# Altium schematic sheet MCU.SchDoc(MCU)
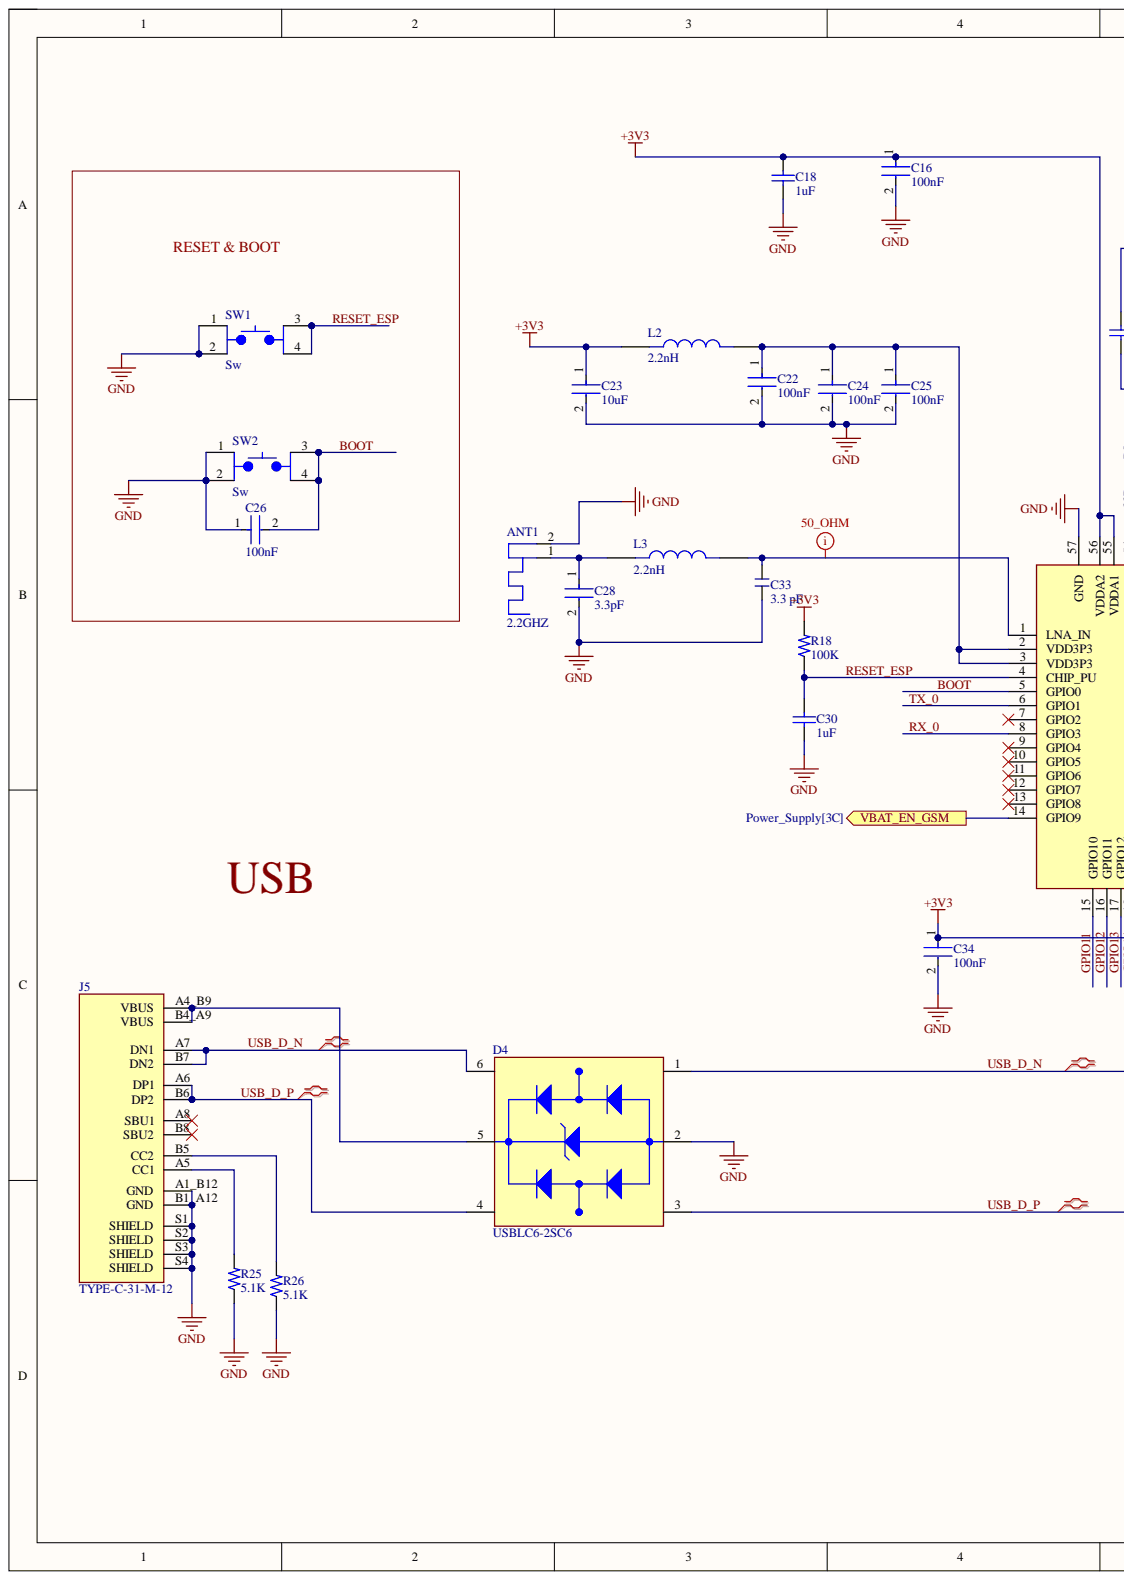
[diagram p0: MCU.SchDoc, left]
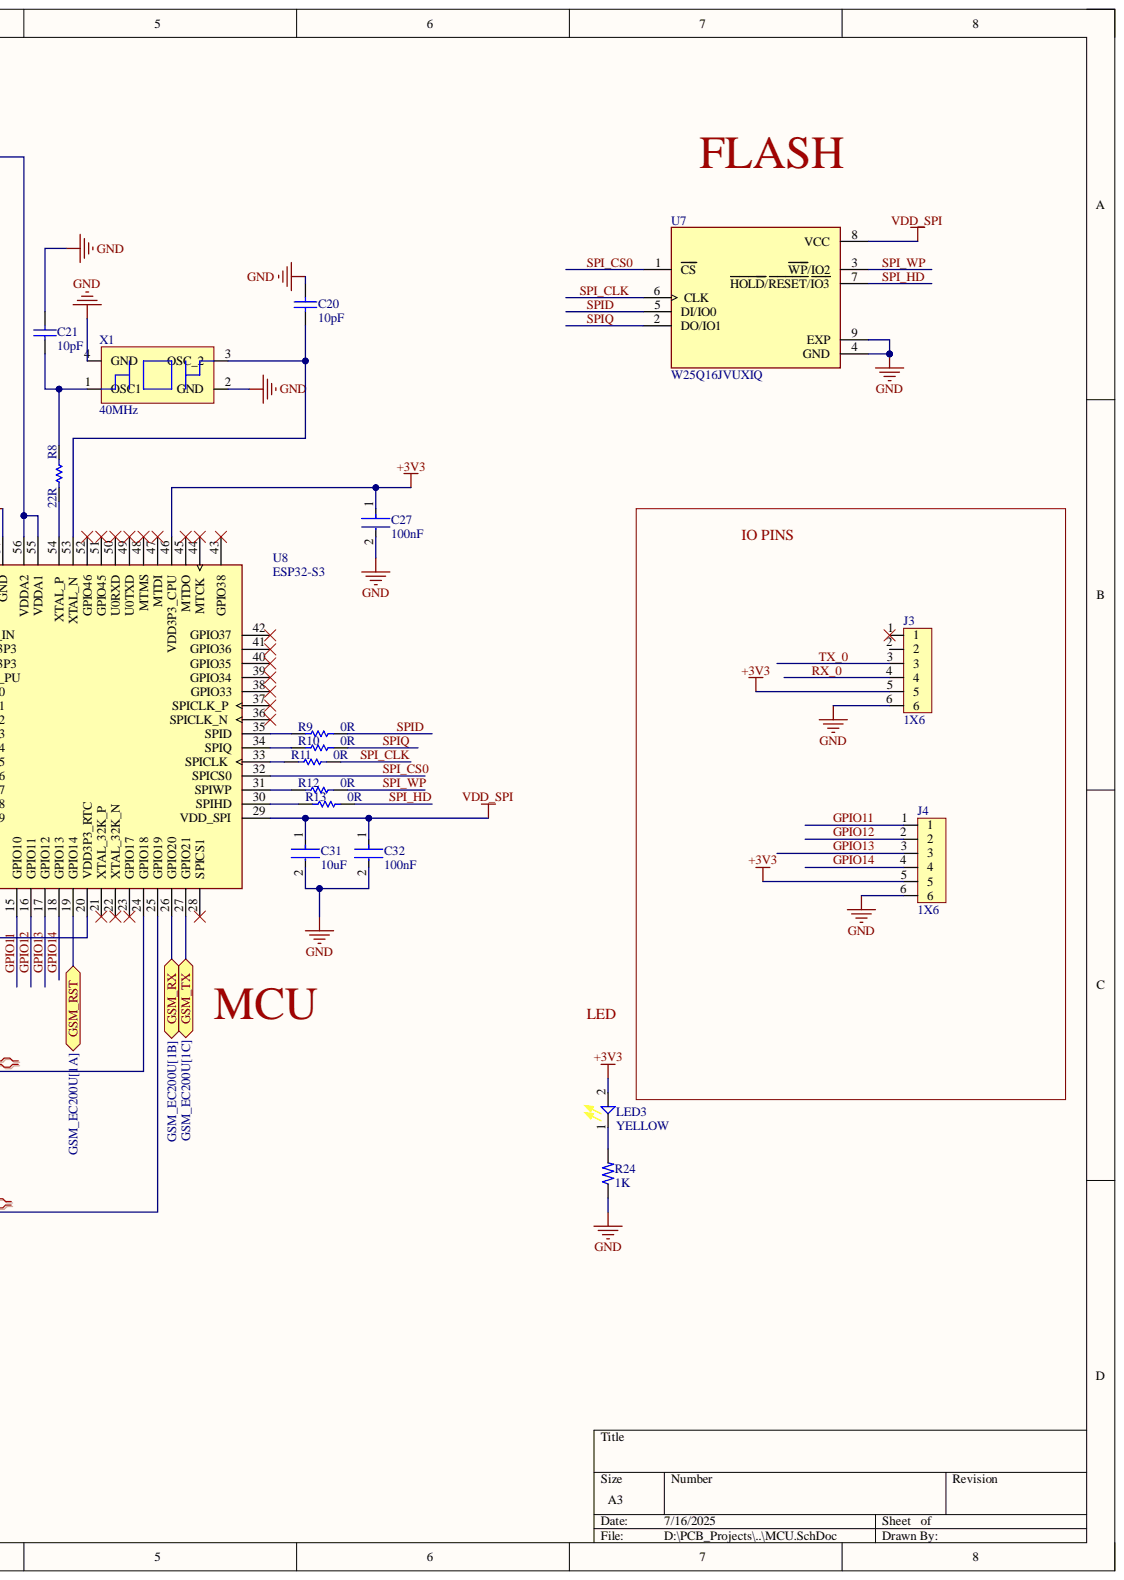
[diagram p1: MCU.SchDoc, right]

## Parts
ANT1  2.2GHZ  [2.4 GHz PCB antenna]
C16  100nF  [FP-CGA3E-0_3-0_1-IPC_A]
C18  1uF  [CAPC1608X90X35LL15T15]
C20  10pF  [CAPC1608X90X35LL10T15]
C21  10pF  [CAPC1608X90X35LL10T15]
C22  100nF  [FP-CGA3E-0_3-0_1-IPC_A]
C23  10uF  [FP-GRM188-0_1-e0_2_0_55-IPC_A]
C24  100nF  [FP-CGA3E-0_3-0_1-IPC_A]
C25  100nF  [FP-CGA3E-0_3-0_1-IPC_A]
C26  100nF  [FP-CGA3E-0_3-0_1-IPC_A]
C27  100nF  [FP-CGA3E-0_3-0_1-IPC_A]
C28  3.3pF  [FP-C0603C-CF-MFG]
C30  1uF  [CAPC1608X90X35LL15T15]
C31  10uF  [FP-GRM188-0_1-e0_2_0_55-IPC_A]
C32  100nF  [FP-CGA3E-0_3-0_1-IPC_A]
C33  3.3 pF  [Capacitor_0805]
C34  100nF  [FP-CGA3E-0_3-0_1-IPC_A]
D4  USBLC6-2SC6  [SOT-23-6]
J3  1X6  [Header_1X6_2.54mm]
J4  1X6  [Header_1X6_2.54mm]
J5  TYPE-C-31-M-12  [TYPE-C-31-M-12]
L2  2.2nH  [Inductor_0603]
L3  2.2nH  [Inductor_0603]
LED3  YELLOW  [LED_YELLOW_0603]
R8  22R  [RESC1608X55X25NL10T15]
R9  0R  [RESC1609X50X30NL8T20]
R10  0R  [RESC1609X50X30NL8T20]
R11  0R  [RESC1609X50X30NL8T20]
R12  0R  [RESC1609X50X30NL8T20]
R13  0R  [RESC1609X50X30NL8T20]
R18  100K  [Resistor_0603]
R24  1K  [Resistor_0805]
R25  5.1K  [Resistor_0805]
R26  5.1K  [Resistor_0805]
SW1  Sw  [SW_Tactile_SMD]
SW2  Sw  [SW_Tactile_SMD]
U7  W25Q16JVUXIQ  [8_USON]
U8  ESP32-S3  [ESP32S3]
X1  40MHz  [SMD2520-4P]

## Ports
GSM_RST, GSM_RX, GSM_TX, VBAT_EN_GSM

## Nets
+3V3: C16.1 C18.2 C23.1 C27.1 C34.1 J3.5 J4.5 L2.1 LED3.2 R18.2 U8.20(VDD3P3_RTC) U8.46(VDD3P3_CPU) U8.55(VDDA1) U8.56(VDDA2)
GND: ANT1.2 C16.2 C18.1 C20.2 C21.2 C22.2 C23.2 C24.2 C25.2 C26.1 C27.2 C28.2 C30.1 C31.2 C32.2 C33.1 C34.2 D4.2 J3.6 J4.6 J5.A1_B12(GND) J5.B1_A12(GND) J5.S1(SHIELD) J5.S2(SHIELD) J5.S3(SHIELD) J5.S4(SHIELD) R24.1 R25.1 R26.1 SW1.1 SW1.2 SW2.1 SW2.2 U7.4(GND) U7.9(EXP) U8.57(GND) X1.2(GND) X1.4(GND)
VDD_SPI: C31.1 C32.1 U7.8(VCC) U8.29(VDD_SPI)
BOOT: C26.2 SW2.3 SW2.4 U8.5(GPIO0)
GPIO11: J4.1 U8.15(GPIO10)
GPIO12: J4.2 U8.16(GPIO11)
GPIO13: J4.3 U8.17(GPIO12)
GPIO14: J4.4 U8.18(GPIO13)
GSM_RST: U8.19(GPIO14) ; port GSM_RST
GSM_RX: U8.26(GPIO20) ; port GSM_RX
GSM_TX: U8.27(GPIO21) ; port GSM_TX
RESET_ESP: C30.2 R18.1 SW1.3 SW1.4 U8.4(CHIP_PU)
RX_0: J3.4 U8.8(GPIO3)
SPI_CLK: R11.2 U7.6(CLK)
SPI_CS0: U7.1(CS) U8.32(SPICS0)
SPI_HD: R13.2 U7.7(HOLD/RESET/IO3)
SPI_WP: R12.2 U7.3(WP/IO2)
SPID: R9.2 U7.5(DI/IO0)
SPIQ: R10.2 U7.2(DO/IO1)
TX_0: J3.3 U8.6(GPIO1)
USB_D_N: D4.1 D4.6 J5.A7(DN1) J5.B7(DN2) U8.24(GPIO18)
USB_D_P: D4.3 D4.4 J5.A6(DP1) J5.B6(DP2) U8.25(GPIO19)
VBAT_EN_GSM: U8.14(GPIO9) ; port VBAT_EN_GSM
NetANT1_1: ANT1.1 C28.1 L3.1
NetC20_1: C20.1 U8.53(XTAL_N) X1.3(OSC_2)
NetC21_1: C21.1 R8.2 X1.1(OSC1)
NetC22_1: C22.1 C24.1 C25.1 L2.2 U8.2(VDD3P3) U8.3(VDD3P3)
NetC33_2: C33.2 L3.2 U8.1(LNA_IN)
NetD4_5: D4.5 J5.A4_B9(VBUS) J5.B4_A9(VBUS)
NetJ5_A5: J5.A5(CC1) R25.2
NetJ5_B5: J5.B5(CC2) R26.2
NetLED3_1: LED3.1 R24.2
NetR8_1: R8.1 U8.54(XTAL_P)
NetR9_1: R9.1 U8.35(SPID)
NetR10_1: R10.1 U8.34(SPIQ)
NetR11_1: R11.1 U8.33(SPICLK)
NetR12_1: R12.1 U8.31(SPIWP)
NetR13_1: R13.1 U8.30(SPIHD)

Not connected: J3 1 2; J5 A8(SBU1) B8(SBU2); U8 7(GPIO2) 9(GPIO4) 10(GPIO5) 11(GPIO6) 12(GPIO7) 13(GPIO8) 21(XTAL_32K_P) 22(XTAL_32K_N) 23(GPIO17) 28(SPICS1) 36(SPICLK_N) 37(SPICLK_P) 38(GPIO33) 39(GPIO34) 40(GPIO35) 41(GPIO36) 42(GPIO37) 43(GPIO38) 44(MTCK) 45(MTDO) 47(MTDI) 48(MTMS) 49(U0TXD) 50(U0RXD) 51(GPIO45) 52(GPIO46)

## Library data of the parts
ANT1 = 2.4 GHz PCB antenna: Component Kind: Standard; Library Name: Schlib1.SchLib
C16 C22 C24-C27 C32 C34 = CMP-2000-07546-2: Cap Ceramic 100nF 50V X7R 10% Pad SMD 0603 +125°C Automotive T/R; Capacitance: 100nF; Case Code (Imperial): 0603; Case Code (Metric): 1608; Case/Package: 0603; Component Kind: Standard; Composition: Ceramic; Depth: 0.8mm; Dielectric: X7R; Height: 0.8mm; Lead Free: Lead Free; Length: 1.6mm; Library Name: Altium Content Vault; Max Operating Temperature: 125°C; Min Operating Temperature: -55°C; Mount: Surface Mount; Package Quantity: 1; Packaging: Tape and Reel; Radiation Hardening: No; Ratings: AEC-Q200; REACH SVHC: No SVHC; Termination: SMD/SMT; Thickness: 0.035inch; Tolerance: 10%; Voltage: 50V; Voltage Rating: 50V; Voltage Rating (DC): 50V; Weight: 7.1E-05oz; Width: 0.8mm
C18 C30 = CMP-2006-02298-2: Manufacturer: Kemet; Part Number: C0603C105K4RACTU; Component Kind: Standard; Library Name: Altium Content Vault
C20 C21 = CMP-2006-02592-1: Manufacturer: Murata; Part Number: GRM1885C1H100JA01D; Component Kind: Standard; Library Name: Altium Content Vault
C23 C31 = CMP-2006-02792-2: Chip Multilayer Ceramic Capacitors for General Purpose, 0603, 10uF, X5R, 15%, 20%, 6.3V; Capacitance: 10uF; Case Code (Imperial): 0603; Case Code (Metric): 1608; Case/Package: 0603; Component Kind: Standard; Depth: 0.8mm; Dielectric: X5R; Height: 0.8mm; Lead Free: Lead Free; Length: 1.6mm; Library Name: Altium Content Vault; Material: Ceramic; Max Operating Temperature: 85°C; Min Operating Temperature: -55°C; Mount: Surface Mount; Package Quantity: 4000; Packaging: Tape and Reel; Radiation Hardening: No; REACH SVHC: No SVHC; Termination: SMD/SMT; Thickness: 0.035inch; Tolerance: 20%; Voltage: 6.3V; Voltage Rating: 6.3V; Voltage Rating (DC): 6.3V; Width: 0.031inch
C28 = CMP-1035-00238-3: CAP CER 3.3PF 50V NP0 0603; Manufacturer: KEMET; Manufacturer Part Number: C0603C339C5GACTU; Automotive Grade: Grade 1; Material: Ceramic; Temperature Characteristic: C0G; Temperature Coefficient: 30ppm/°C; Tolerance: 0.25 pF; Automotive: Yes; Capacitance: 3.3 pF; Category: Cap; Component Kind: Standard; ComponentLink2Description: Datasheet; ComponentLink2URL: https://api.kemet.com/component-edge/download/datasheet/C0603C100J5GACAUTO.pdf; Device Class L1: Passive Components; Device Class L2: Capacitors; Device Class L3: Ceramic Capacitors; Digikey Description: CAP CER 3.3PF 50V NP0 0603; Height: 0.87mm; Lead Free: Yes; Library Name: Altium Content Vault; Mouser Description: Multilayer Ceramic Capacitors MLCC - SMD/SMT 50volts 3.3pF C0G 0.25%; Octopart: https://octopart.com/c0603c339c5gactu-kemet-3701205; Package: C0603C-CF; RoHS: TRUE; Temperature: -55°C to +125°C; Temperature Range High: +125°C; Temperature Range Low: -55°C; Value: 3.3 pF; Voltage Rating: 50 V
C33 = CAP 3.3 pF 0805: Multilayer Ceramic Capacitor, 3.3 pF, 50 V, ± 0.1pF, C0G (NP0), 0805 [2012 Metric]; Manufacturer: Yageo; Manufacturer Part Number: CC0805BRNPO9BN3R3; Category: Ceramic Capacitors; Component Kind: Standard; ComponentLink1Description: http://www.mouser.com/ds/2/447/Yageo_UPY-GP_NP0_16V-to-50V_9-358835.pdf?src-supplier=Mouser; ComponentLink1URL: http://www.mouser.com/ds/2/447/Yageo_UPY-GP_NP0_16V-to-50V_9-358835.pdf?src-supplier=Mouser; ComponentLink2Description: https://datasheet.octopart.com/CC0805BRNPO9BN3R3-Yageo-datasheet-151948684.pdf?src-supplier=Newark; ComponentLink2URL: https://datasheet.octopart.com/CC0805BRNPO9BN3R3-Yageo-datasheet-151948684.pdf?src-supplier=Newark; ComponentLink3Description: https://datasheet.octopart.com/CC0805BRNPO9BN3R3-Yageo-datasheet-151948684.pdf?src-supplier=Farnell; ComponentLink3URL: https://datasheet.octopart.com/CC0805BRNPO9BN3R3-Yageo-datasheet-151948684.pdf?src-supplier=Farnell; ComponentLink4Description: http://www.yageo.com/documents/recent/UPY-GP_NP0_16V-to-50V_8.pdf?src-supplier=Avnet; ComponentLink4URL: http://www.yageo.com/documents/recent/UPY-GP_NP0_16V-to-50V_8.pdf?src-supplier=Avnet; ComponentLink5Description: https://www.yageo.com/upload/media/product/productsearch/datasheet/mlcc/UPY-GP_NP0_16V-to-50V_18.pdf?src-supplier=Digikey; ComponentLink5URL: https://www.yageo.com/upload/media/product/productsearch/datasheet/mlcc/UPY-GP_NP0_16V-to-50V_18.pdf?src-supplier=Digikey; ComponentLink6Description: http://www.yageo.com/exep/pages/download/literatures/ProductSelectionGuide%202014_Final_2.pdf?src-supplier=Digikey; ComponentLink6URL: http://www.yageo.com/exep/pages/download/literatures/ProductSelectionGuide%202014_Final_2.pdf?src-supplier=Digikey; ComponentLink7Description: http://www.yageo.com/documents/recent/UPY-GP_NP0_16V-to-50V_9.pdf?src-supplier=Future+Electronics; ComponentLink7URL: http://www.yageo.com/documents/recent/UPY-GP_NP0_16V-to-50V_9.pdf?src-supplier=Future+Electronics; ComponentLink8Description: https://datasheet.octopart.com/CC0805BRNPO9BN3R3-Yageo-datasheet-137700082.pdf?src-supplier=Future+Electronics; ComponentLink8URL: https://datasheet.octopart.com/CC0805BRNPO9BN3R3-Yageo-datasheet-137700082.pdf?src-supplier=Future+Electronics; Halogen Free: 5694HalogenFree; Library Name: Schlib1.SchLib; Pricing: 1=0,13, 10=0,075, 100=0,0453, 1000=0,03028 (USD); RoHS: Yes; Stock: 19725; Supplier: Digikey; Supplier Part Number: 311-4130-1-ND
D4 = USBLC6-2SC6: ESD Suppressor Diode Array Dual Uni-Dir 15kV Air Gap/15kV Contact Disc 6-Pin SOT-23 T/R; Manufacturer: STMicroelectronics; Breakdown Voltage: 6V; Clamping Voltage: 17V; Data Rate: 480Mbps; Direction: Unidirectional,Bidirectional; ESD Protection: Yes; Leakage Current: 150nA; Max Repetitive Reverse Voltage (Vrrm): 5.25V; Number of Bidirectional Channels: 2; Number of Elements: 1; Operating Supply Voltage: 1.1V; Peak Pulse Current: 5A; Test Current: 1mA; Value: 3.5pF; Working Voltage: 5V; Case/Package: SOT-23; Category: TVS Diodes; Clamping Voltage-Max: 17V; Component Kind: Standard; ComponentLink1Description: https://datasheet.ciiva.com/1268/1690354-1268384.pdf?src-supplier=Element14; ComponentLink1URL: https://datasheet.ciiva.com/1268/1690354-1268384.pdf?src-supplier=Element14; ComponentLink2Description: https://datasheet.ciiva.com/4508/cd00050750-113117-4508433.pdf?src-supplier=Mouser; ComponentLink2URL: https://datasheet.ciiva.com/4508/cd00050750-113117-4508433.pdf?src-supplier=Mouser; ComponentLink3Description: https://datasheet.ciiva.com/15143/getdatasheetpartid-148465-15143789.pdf?src-supplier=Verical; ComponentLink3URL: https://datasheet.ciiva.com/15143/getdatasheetpartid-148465-15143789.pdf?src-supplier=Verical; ComponentLink4Description: https://datasheet.ciiva.com/16200/cd00050750-16200026.pdf?src-supplier=Future+Electronics; ComponentLink4URL: https://datasheet.ciiva.com/16200/cd00050750-16200026.pdf?src-supplier=Future+Electronics; ComponentLink5Description: https://datasheet.ciiva.com/41444/001184109-da-01-en-ic-esd-protecti-usblc6-2sc6-sot-23-6-stm-41444156.pdf?src-supplier=Conrad; ComponentLink5URL: https://datasheet.ciiva.com/41444/001184109-da-01-en-ic-esd-protecti-usblc6-2sc6-sot-23-6-stm-41444156.pdf?src-supplier=Conrad; Depth: 1.75mm; Height: 1.3mm; Lead Free: Lead Free; Length: 3.05mm; Library Name: Schlib1.SchLib; Manufacturer Part Number: USBLC6-2SC6; Max Breakdown Voltage: 6V; Max Operating Temperature: 125°C; Max Reverse Leakage Current: 150nA; Min Breakdown Voltage: 6V; Min Operating Temperature: -40°C; Mounting Technology: Surface Mount; Number of Channels: 2; Package Quantity: 3000; Packaging: Tape and Reel; Pins: 6; Polarity: Unidirectional,Bidirectional; Power Line Protection: Yes; Pricing: 3000=0,0796, 6000=0,0796, 9000=0,0796 (USD); Radiation Hardening: No; Reach SVHC Compliant: No; Reverse Breakdown Voltage: 6V; Reverse Standoff Voltage: 5.25V; RoHS: Yes; RoHS Compliant: Yes; Series: USB; Stock: 222000; Supplier: Arrow Electronics; Supplier Part Number: USBLC6-2SC6; Termination: SMD/SMT; Width: 1.75mm
J3 J4 = Header Male 1X6: THT Vertical Pin Header WR-PHD, Pitch 2.54 mm, Single Row, 6 pins; Manufacturer: Wurth Electronics; Manufacturer Part Number: 61300611121; Contact Resistance: 20mR; Current Rating: 3A; Gender: 29Gender; Number of Contacts: 6; Operating Supply Voltage: 250V; Pitch: 0.1inch; Category: Headers and Wire Housings; Color: Black; Component Kind: Standard; ComponentLink1Description: http://www.mouser.com/ds/2/445/6130xx11121-538006.pdf?src-supplier=Mouser; ComponentLink1URL: http://www.mouser.com/ds/2/445/6130xx11121-538006.pdf?src-supplier=Mouser; ComponentLink2Description: http://www.farnell.com/cad/1737268.pdf?src-supplier=Element14; ComponentLink2URL: http://www.farnell.com/cad/1737268.pdf?src-supplier=Element14; ComponentLink3Description: https://datasheet.octopart.com/61300611121-W%C3%BCrth-Elektronik-datasheet-21154836.pdf?src-supplier=Element14; ComponentLink3URL: https://datasheet.octopart.com/61300611121-W%C3%BCrth-Elektronik-datasheet-21154836.pdf?src-supplier=Element14; ComponentLink4Description: https://katalog.we-online.de/em/datasheet/6130xx11121.pdf?src-supplier=Digikey; ComponentLink4URL: https://katalog.we-online.de/em/datasheet/6130xx11121.pdf?src-supplier=Digikey; Contact Gender: Male; Contact Material: Copper Alloy,Copper; Contact Mating Length: 0.236inch; Contact Plating: Gold; Contact Type: Male Pin; Height: 8.89mm; Housing Material: PBT; Lead Free: 5697LeadFree; Library Name: Schlib1.SchLib; Max Operating Temperature: 125°C; Min Operating Temperature: -40°C; Mounting Technology: Through Hole; Number of Positions: 6; Number of Rows: 1; Orientation: 5495OrientationType; Packaging: Bulk; Pricing: 1=0,35, 10=0,298, 50=0,207, 100=0,187, 1000=0,171, 10000=0,17 (USD); Reach SVHC Compliant: Unknown; RoHS: Yes; RoHS Compliant: Yes; Series: WR-PHD; Stock: 8481; Supplier: Mouser; Supplier Part Number: 710-61300611121; Termination: Through Hole; Voltage Rating: 250V
J5 = TYPE-C-31-M-12: Manufacturer: HRO Electronics; Category: LDO; Component Kind: Standard; Library Name: Schlib1.SchLib; Manufacturer Part Number: TYPE-C-31-M-12; Pricing: 5=0,171, 50=0,1346, 150=0,1168, 1000=0,0966, 2000=0,0923, 5000=0,0896 (USD); RoHS: No; Stock: 137245; Supplier: LCSC; Supplier Part Number: C165948
L2 L3 = Inductor 2.2nH 0603: 2nH 0603 Inductors (SMD) ROHS; Manufacturer: FH (Guangdong Fenghua Advanced Tech); Manufacturer Part Number: VHF160808H2N0ST; Component Kind: Standard; Library Name: Schlib1.SchLib
LED3 = LED_Yellow_0603: TJ-S1608SW6TGLCCW-A5; Manufacturer: Togialed; Component Kind: Standard; Library Name: Schlib1.SchLib; Manufacturer Part Number: TJ-S1608SW6TGLCCW-A5; Pricing: 10=0,0384, 100=0,0311, 300=0,0275, 1000=0,0248, 4000=0,0209, 8000=0,0198 (USD); RoHS: No; Stock: 15460; Supplier: LCSC; Supplier Part Number: C192669
R8 = CMP-1659-00033-1: Chip Resistor, 22 Ohm, +/- 1%, 0.1 W, -55 to 155 degC, 0603 (1608 Metric), RoHS, Tape and Reel; Case\Package: SIP; Max Input Voltage: 1.4 V; Max Ouput Current: 8 A; Component Kind: Standard; ComponentLink1Description: Manufacturer URL; ComponentLink1URL: http://www.yageo.com/; ComponentLink2Description: Datasheet; ComponentLink2URL: http://www.yageo.com/documents/recent/PYu-RC_Group_51_RoHS_L_04.pdf; DatasheetVersion: Rev. 4, 02/2016; Height: 19.6 mm; Length: 18.5 mm; Library Name: Altium Content Vault; Max Operating Temperature: 100 degC; Min Operating Temperature: -25 degC; Mount: Through Hole; Output Current: 8 A; Package Quantity: 200; PackageDescription: 2-Pin Surface Mount Device, Body 1.6 x 0.8 mm; PackageReference: 0603; Packaging: Rail/Tube; Pins: 4; Radiation Hardening: No; REACH SVHC: Unknown; RoHSCompliant: true
R9-R13 = CMP-2000-03816-5: Chip Resistor, 0 Ohm, Jumper, 100 mW, -55 to 155 degC, 0603 (1608 Metric), RoHS, Tape and Reel; Manufacturer: Vishay Semiconductor; Voltage Rating: 75 V; Component Kind: Standard; ComponentLink1Description: Manufacturer URL; ComponentLink1URL: http://www.vishay.com/; ComponentLink2Description: Datasheet; ComponentLink2URL: http://www.vishay.com/docs/20035/dcrcwe3.pdf; DatasheetVersion: 06/2012; Height: 0.45 mm; Length: 1.55 mm; Library Name: Altium Content Vault; Max Operating Temperature: 155 degC; Min Operating Temperature: -55 degC; Mount: Surface Mount; Mounting Technology: Surface Mount; Package Quantity: 5000; PackageDescription: 2-Pin Surface Mount Device, Body 1.575 x 0.85 mm; PackageReference: 0603; Packaging: Tape and Reel; Pins: 2; REACH SVHC: Unknown; RoHSCompliant: true
R18 = R_100K_0603: Res Thick Film 0603 100K Ohm 1% 0.1W(1/10W) ±100ppm/C Pad SMD Automotive T/R; Manufacturer: Stackpole Electronics; Manufacturer Part Number: RMCF0603FT100K; Max Power Dissipation: 100mW; Temperature Coefficient: 100ppm/°C; Value: 100kR; Voltage Rating: 75V; Case Code (Imperial): 0603; Case Code (Metric): 1608; Case/Package: 0603; Category: Chip SMD Resistors; Component Kind: Standard; ComponentLink1Description: https://datasheet.ciiva.com/15193/getdatasheetpartid-362597-15193437.pdf?src-supplier=Verical; ComponentLink1URL: https://datasheet.ciiva.com/15193/getdatasheetpartid-362597-15193437.pdf?src-supplier=Verical; Construction: Rectangular; Contact Plating: Tin,Matte; Depth: 0.8mm; Features: Automotive AEC-Q200; Height: 0.022inch; Lead Free: 5697LeadFree; Length: 1.55mm; Library Name: Schlib1.SchLib; Max Operating Temperature: 155°C; Military Standard: Not; Min Operating Temperature: -55°C; Mounting Technology: Surface Mount; Number of Terminations: 2; Packaging: Tape and Reel; Pricing: 1=0,1, 10=0,02, 100=0,0091, 1000=0,0048, 2500=0,00386 (USD); Radiation Hardening: No; RoHS: Yes; RoHS Compliant: Yes; Series: RMCF; Stock: 722640; Supplier: Digikey; Supplier Part Number: RMCF0603FT100KCT-ND; Tolerance: 1%; Width: 0.031inch
R24 = Res 1K 0805: 125Mw Thick Film Resistors 100Ppm/ 1% 1K 0805 Chip Resistor Surface Mount Rohs; Manufacturer: Yageo; Manufacturer Part Number: RC0805FR-071KL; Temperature Coefficient: 100ppm/°C; Value: 1kR; Voltage Rating: 150V; Case Code (Imperial): 0805; Case Code (Metric): 2012; Case/Package: 0805; Category: Chip SMD Resistors; Component Kind: Standard; ComponentLink1Description: https://datasheet.ciiva.com/7941/1686128-7941719.pdf?src-supplier=Element14; ComponentLink1URL: https://datasheet.ciiva.com/7941/1686128-7941719.pdf?src-supplier=Element14; ComponentLink2Description: https://datasheet.ciiva.com/46385/444164-46385020.pdf?src-supplier=Element14; ComponentLink2URL: https://datasheet.ciiva.com/46385/444164-46385020.pdf?src-supplier=Element14; ComponentLink3Description: https://datasheet.ciiva.com/2456/pyu-r-int-thick-7-2456976.pdf?src-supplier=Digikey; ComponentLink3URL: https://datasheet.ciiva.com/2456/pyu-r-int-thick-7-2456976.pdf?src-supplier=Digikey; ComponentLink4Description: https://datasheet.ciiva.com/2456/pyu-r-int-thick-7-2456977.pdf?src-supplier=Digikey; ComponentLink4URL: https://datasheet.ciiva.com/2456/pyu-r-int-thick-7-2456977.pdf?src-supplier=Digikey; ComponentLink5Description: https://datasheet.ciiva.com/2456/pyu-r-int-thick-7-2456975.pdf?src-supplier=Digikey; ComponentLink5URL: https://datasheet.ciiva.com/2456/pyu-r-int-thick-7-2456975.pdf?src-supplier=Digikey; ComponentLink6Description: https://datasheet.ciiva.com/16850/rc0805-16850409.pdf?src-supplier=Future+Electronics; ComponentLink6URL: https://datasheet.ciiva.com/16850/rc0805-16850409.pdf?src-supplier=Future+Electronics; Construction: Rectangular; Depth: 1.25mm; Features: Moisture Resistant; Height: 0.024inch; Lead Free: Lead Free; Length: 0.079inch; Library Name: Schlib1.SchLib; Max Operating Temperature: 155°C; Max Power Dissipation: 125mW; Military Standard: Not; Min Operating Temperature: -55°C; Number of Terminations: 2; Package Quantity: 1; Packaging: Tape and Reel; Pins: 2; Power Rating: 125mW; Pricing: 10=0,014, 25=0,012, 50=0,01, 100=0,009 (USD); Reach SVHC Compliant: No; Resistance Tolerance: 1%; Resistor Type: Thick Film; RoHS: Yes; RoHS Compliant: Yes; Series: RC; Stock: 255910; Supplier: Avnet; Supplier Part Number: 82K7536; Termination: SMD/SMT; Tolerance: 1%; Voltage Rating (AC): 150V; Voltage Rating (DC): 150V; Width: 0.049inch
R25 R26 = RES 5.1K 0805: Res Thin Film 0805 5.1K Ohm 0.1% 1/8W ±10ppm/°C Molded SMD Paper T/R; Manufacturer: Yageo; Manufacturer Part Number: RT0805BRD075K1L; Max Power Dissipation: 125mW; Temperature Coefficient: 25ppm/°C; Value: 5.1kR; Voltage Rating: 150V; Case Code (Imperial): 0805; Case Code (Metric): 2012; Case/Package: 0805; Category: Chip SMD Resistors; Component Kind: Standard; ComponentLink1Description: https://datasheet.ciiva.com/12810/pyu-rt-1-to-0-05-rohs-l-4-12810709.pdf?src-supplier=Mouser; ComponentLink1URL: https://datasheet.ciiva.com/12810/pyu-rt-1-to-0-05-rohs-l-4-12810709.pdf?src-supplier=Mouser; ComponentLink2Description: https://datasheet.ciiva.com/2585/pyu-rt-1-to-0-05-rohs-l-4-2585107.pdf?src-supplier=Digikey; ComponentLink2URL: https://datasheet.ciiva.com/2585/pyu-rt-1-to-0-05-rohs-l-4-2585107.pdf?src-supplier=Digikey; ComponentLink3Description: https://datasheet.ciiva.com/46635/pyu-rt-1-to-0-05-rohs-l-5-46635340.pdf?src-supplier=Digikey; ComponentLink3URL: https://datasheet.ciiva.com/46635/pyu-rt-1-to-0-05-rohs-l-5-46635340.pdf?src-supplier=Digikey; Features: Moisture Resistant; Height: 0.024inch; Lead Free: Lead Free; Length: 0.079inch; Library Name: Schlib1.SchLib; Max Operating Temperature: 155°C; Min Operating Temperature: -55°C; Number of Terminations: 2; Package Quantity: 5000; Packaging: Tape and Reel; Power Rating: 125mW; Pricing: 1=0,14, 10=0,099, 50=0,0918, 100=0,0826, 500=0,06324, 1000=0,05712 (USD); RoHS: Yes; RoHS Compliant: Yes; Series: RT; Stock: 24867; Supplier: Digikey; Supplier Part Number: YAG1938CT-ND; Termination: SMD/SMT; Voltage Rating (DC): 150V; Weight: 0.000194oz; Width: 1.25mm
SW1 SW2 = SW_Tactile_SPST: TL3305A Series SPST 12 V 4.5 x 4.5 mm 260 gf Top Actuated SMT Tactile Switch; Manufacturer: E-Switch; Manufacturer Part Number: TL3305AF260QG; Actuator Type: Standard; Illumination Type: Non-Illuminated; Mechanical Life: 200000Cycles; Operating Force: 260gf; Switch Function: Off-Mom; Switch Travel: 0.2mm; Category: Tactile Switches; Component Kind: Standard; ComponentLink1Description: http://www.farnell.com/datasheets/2554191.pdf?src-supplier=Farnell; ComponentLink1URL: http://www.farnell.com/datasheets/2554191.pdf?src-supplier=Farnell; ComponentLink2Description: http://www.farnell.com/datasheets/2554191.pdf?src-supplier=Newark; ComponentLink2URL: http://www.farnell.com/datasheets/2554191.pdf?src-supplier=Newark; ComponentLink3Description: http://spec_sheets.e-switch.com/specs/P010471.pdf?src-supplier=Mouser; ComponentLink3URL: http://spec_sheets.e-switch.com/specs/P010471.pdf?src-supplier=Mouser; ComponentLink4Description: http://www.farnell.com/datasheets/2554191.pdf?src-supplier=Element14; ComponentLink4URL: http://www.farnell.com/datasheets/2554191.pdf?src-supplier=Element14; ComponentLink5Description: http://spec_sheets.e-switch.com/specs/P010471.pdf?src-supplier=Digikey; ComponentLink5URL: http://spec_sheets.e-switch.com/specs/P010471.pdf?src-supplier=Digikey; ComponentLink6Description: http://spec_sheets.e-switch.com/specs/P010471.pdf?src-supplier=NAC+Semi; ComponentLink6URL: http://spec_sheets.e-switch.com/specs/P010471.pdf?src-supplier=NAC+Semi; Lead Free: Lead Free; Library Name: Schlib1.SchLib; Max Operating Temperature: 70°C; Min Operating Temperature: -20°C; Mounting Technology: Surface Mount; Packaging: Cut Tape; Pricing: 1=0,2, 10=0,176, 25=0,1664, 100=0,153, 250=0,14456, 500=0,1385, 1000=0,13264 (USD); RoHS: No; Series: TL3305; Stock: 97166; Supplier: Digikey; Supplier Part Number: EG5353CT-ND; Termination: Gull Wing; Throw Configuration: SPST
U7 = W25Q16JVUXIQ TR: NOR Flash Serial (SPI, Dual SPI, Quad SPI) 3V/3.3V 16M-bit 2M x 8 6ns 8-Pin USON EP T/R; Manufacturer: Winbond; Category: Memory; Component Kind: Standard; Library Name: Schlib1.SchLib; Manufacturer Part Number: W25Q16JVUXIQ TR; Pricing: 1=0,51, 10=0,477, 25=0,464, 50=0,4542, 100=0,4444, 250=0,43128, 500=0,4213, 1000=0,41135 (USD); RoHS: No; Stock: 6291; Supplier: Digikey; Supplier Part Number: 256-W25Q16JVUXIQTRCT-ND
U8 = ESP32-S3R8: RF System on a Chip - SoC SMD IC, Dual-Core MCU, Wi-Fi 2.4G BLE 5.0 Combo; Manufacturer: Espressif Systems; Category: RF Receivers, Transceivers; Component Kind: Standard; ComponentLink1Description: https://datasheet.octopart.com/ESP32-S3R8-Espressif-Systems-datasheet-165560561.pdf?src-supplier=TME; ComponentLink1URL: https://datasheet.octopart.com/ESP32-S3R8-Espressif-Systems-datasheet-165560561.pdf?src-supplier=TME; Library Name: Schlib1.SchLib; Manufacturer Part Number: ESP32-S3R8; Pricing: 1=2,51 (USD); RoHS: No; Supplier: Digikey; Supplier Part Number: 1904-ESP32-S3R8DKR-ND
X1 = Q24FA20H0020900: Manufacturer: Seiko; Component Kind: Standard; Library Name: Schlib1.SchLib; Manufacturer Part Number: Q24FA20H0020900; RoHS: No; Supplier: LCSC; Supplier Part Number: C255973
